# Altium schematic sheet Conversor_DC_DC_3,3V.SchDoc
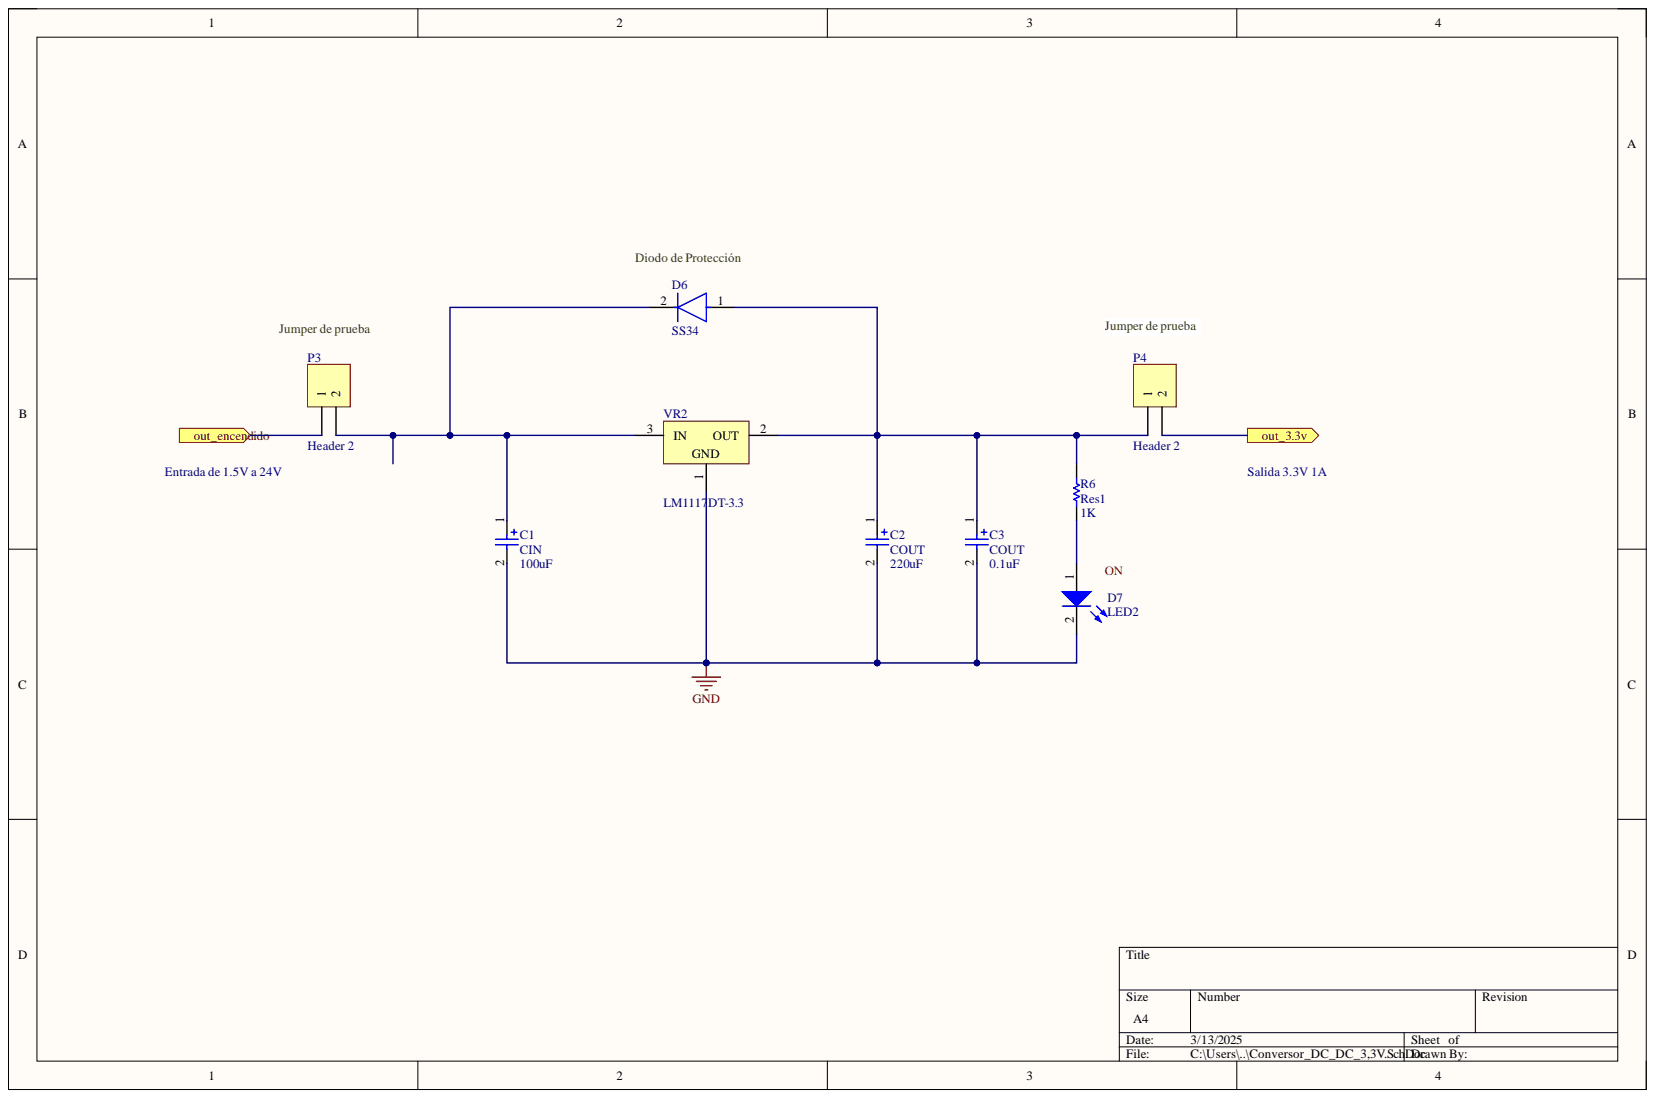
[diagram p0: Conversor_DC_DC_3,3V.SchDoc]

## Parts
C1  CIN  value 100uF  [CAPACITOR ALUMINUM]
C2  COUT  value 220uF  [CAPACITOR ALUMINUM]
C3  COUT  value 0.1uF  [CC1206]
D6  SS34  [SS34 DIODE]
D7  LED2  [LED 0805]
P3  Header 2  [Header 1X2]
P4  Header 2  [Header 1X2]
R6  Res1  value 1K  [RESISTOR 1206]
VR2  LM1117DT-3.3  [LM1117DT-3.3]

## Ports
out_3.3v, out_encendido

## Nets
GND: C1.2 C2.2 C3.2 D7.2 VR2.1
out_3.3v: P4.2 ; port out_3.3v
out_encendido: P3.1 ; port out_encendido
NetC1_1: C1.1 D6.2 P3.2 VR2.3
NetC2_1: C2.1 C3.1 D6.1 P4.1 R6.2 VR2.2
NetD7_1: D7.1 R6.1

## Library data of the parts
C1 = Cap Pol1: Polarized Capacitor (Radial); Component Kind: Standard; LatestRevisionDate: 17-Jul-2002; LatestRevisionNote: Re-released for DXP Platform.; PackageDescription: Polarized Capacitor (Radial); 2 Leads; PackageReference: RB7.6-15; Published: 8-Jun-2000; Signal Integrity: Cap Pol; Simulation: CAP; Library Name: PCB_Proyecto_Fuente_Voltaje.SCHLIB; Publisher: Altium Limited
C2 = Cap Pol1_1: Polarized Capacitor (Radial); Component Kind: Standard; LatestRevisionDate: 17-Jul-2002; LatestRevisionNote: Re-released for DXP Platform.; PackageDescription: Polarized Capacitor (Radial); 2 Leads; PackageReference: RB7.6-15; Published: 8-Jun-2000; Signal Integrity: Cap Pol; Simulation: CAP; Library Name: PCB_Proyecto_Fuente_Voltaje.SCHLIB; Publisher: Altium Limited
C3 = Cap Pol1_2: Polarized Capacitor (Radial); Component Kind: Standard; LatestRevisionDate: 17-Jul-2002; LatestRevisionNote: Re-released for DXP Platform.; PackageDescription: Polarized Capacitor (Radial); 2 Leads; PackageReference: RB7.6-15; Published: 8-Jun-2000; Signal Integrity: Cap Pol; Simulation: CAP; Library Name: PCB_Proyecto_Fuente_Voltaje.SCHLIB; Publisher: Altium Limited
D6 = CMP-02539-000012-1: DIODE SCHOTTKY 40V 3A DO214AB; Manufacturer: Vishay; Manufacturer Part Number: SS34-E3/57T; Max Junction Temp: +150°C; Max Surge Forward Current: 100A; Peak Reverse Current: 0.5mA; Standoff Height: 0mm; Automotive: No; Category: Diode; Component Kind: Standard; ComponentLink2Description: Datasheet; ComponentLink2URL: http://www.vishay.com/docs/88751/ss32.pdf; Device Class L1: Discrete Semiconductors; Device Class L2: Diodes; Device Class L3: Schottky Diodes; Digikey Description: DIODE SCHOTTKY 40V 3A DO214AB; Footprint Url: http://www.vishay.com/docs/95402/smc.pdf; Forward Current: 3A; Height: 2.62mm; Lead Free: Yes; Max Repetitive Reverse Voltage: 40V; Mouser Description: Schottky Diodes & Rectifiers 3.0 Amp 40 Volt; Octopart: https://octopart.com/ss34-e3%2F57t-vishay-39552907; Package: 95402; RoHS: TRUE; Temperature: -55°C to +150°C; Temperature Range High: +150°C; Temperature Range Low: -55°C; Library Name: biblioteca_esquematica.SchLib
D7 = LED0: Typical INFRARED GaAs LED; Component Kind: Standard; LatestRevisionDate: 08-Jul-2005; LatestRevisionNote: Mech Layer 1 removed.; PackageDescription: LED; 2 Leads; PackageReference: LED-0; Published: 8-Jun-2000; Simulation: LED0; Library Name: PCB_Proyecto_Fuente_Voltaje.SCHLIB; Publisher: Altium Limited
P3 P4 = TERMINAL BLOCK: Header, 2-Pin; Component Kind: Standard; LatestRevisionDate: 17-Jul-2002; LatestRevisionNote: Re-released for DXP Platform.; Signal Integrity: Connector; Library Name: PCB_Proyecto_Fuente_Voltaje.SCHLIB; Publisher: Altium Limited
R6 = Res1: Resistor; Component Kind: Standard; LatestRevisionDate: 17-Jul-2002; LatestRevisionNote: Re-released for DXP Platform.; PackageDescription: Axial Device, Thru-Hole; 2 Leads; 0.3 in Pin Spacing; PackageReference: AXIAL-0.3; Published: 8-Jun-2000; Signal Integrity: Res; Simulation: RESISTOR; Library Name: PCB_Proyecto_Fuente_Voltaje.SCHLIB; Publisher: Altium Limited
VR2 = LM1117DT-3.3: 800mA Low-Dropout Linear Regulator, 3-pin TO-252; Manufacturer: National Semiconductor; CompType: LDO IC; PackageTopMarking: NSUZXYTT*LM1117*DT-3.3; PowerWise: N; Code_JEDEC: TO-252-AA; Component Kind: Standard; ComponentLink1Description: LM1117 Datasheet; ComponentLink1URL: http://www.national.com/ds/LM/LM1117.pdf; ComponentLink2Description: LM1117 Web Page; ComponentLink2URL: http://www.national.com/pf/LM/LM1117.html; ComponentLink3Description: TD03B Package Page; ComponentLink3URL: http://www.national.com/packaging/folders/td03b.html; DatasheetVersion: Apr-2006; Manufacturer Part Number: LM1117DT-3.3; PackageDescription: TO252, Molded, Jedec, 6.8 x 6.10 x 2.30mm Body, 3 Lead, 2.28mm Pitch, Surface Mount; PackageReference: TD03B; PackageVersion: revD, Feb-2009; PinCount: 3; RevisionNote: Component URLs added; RoHS: N; Library Name: PCB_Proyecto_Fuente_Voltaje.SCHLIB
